# Altium schematic sheet USB-C_BREAKOUT_COMPONENTS.SchDoc(TOP)
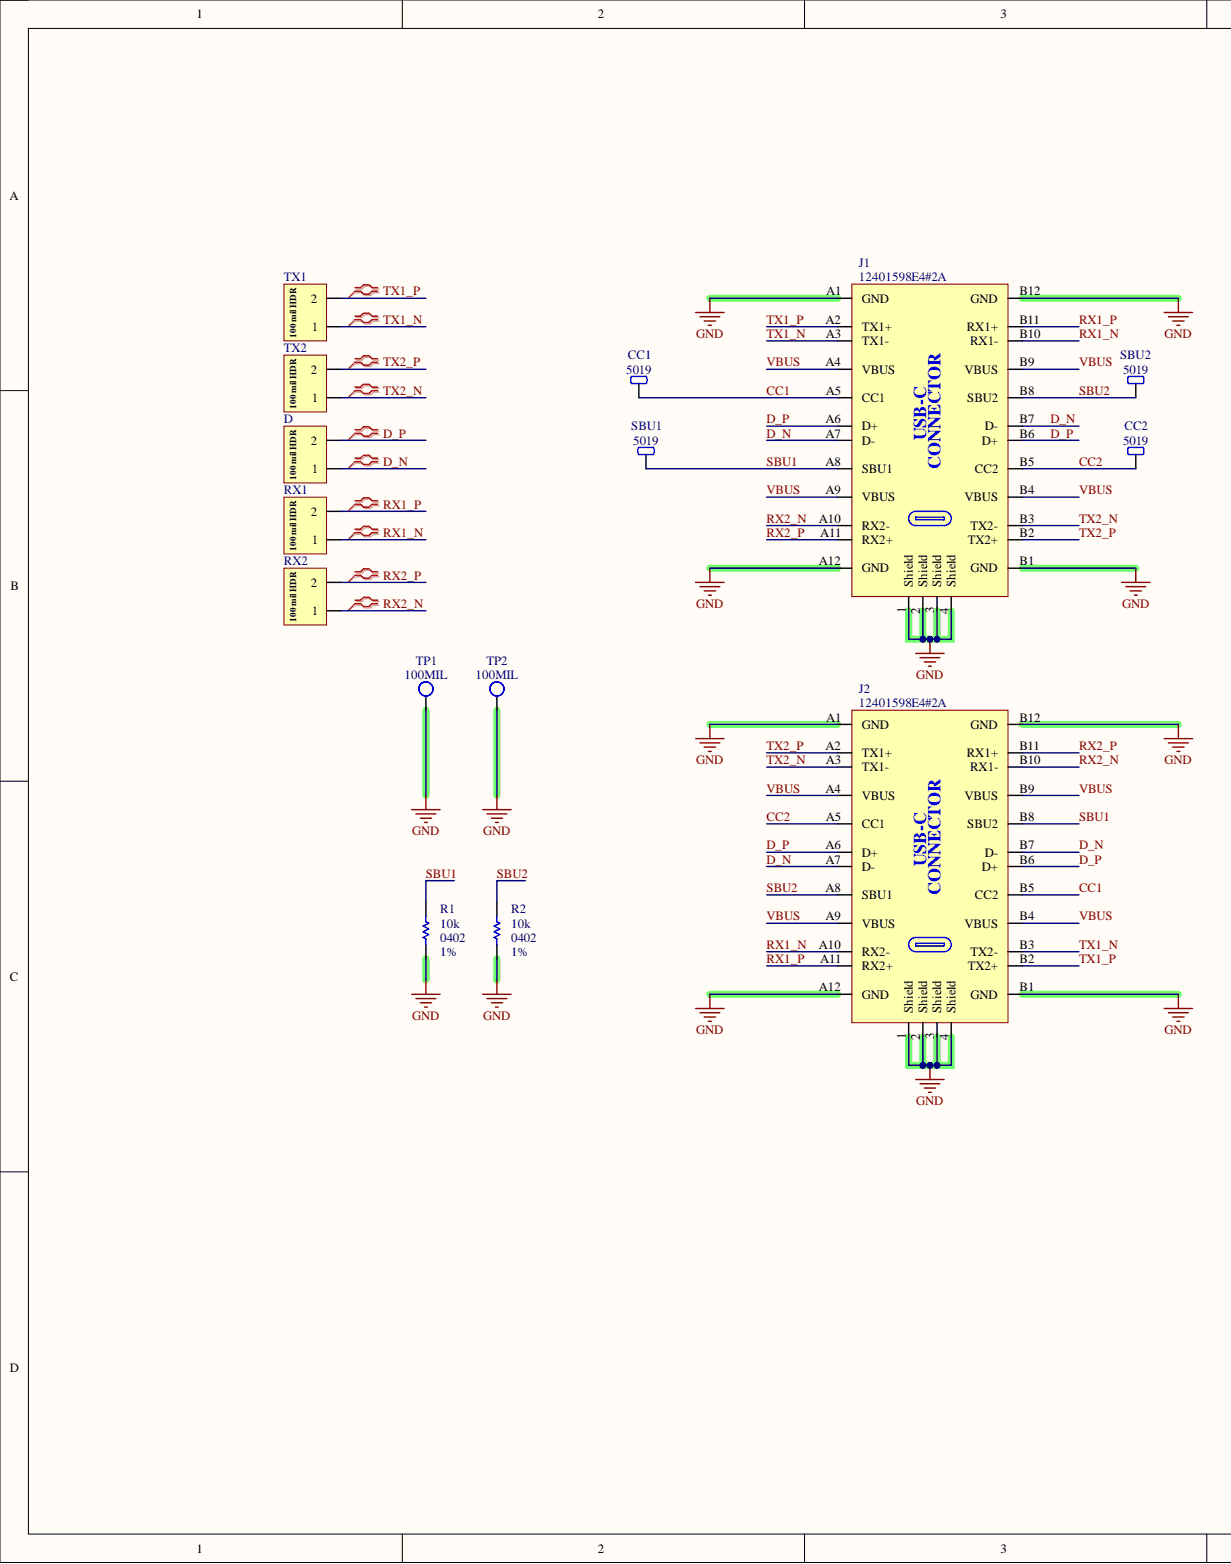
[diagram p0: USB-C_BREAKOUT_COMPONENTS.SchDoc, left]
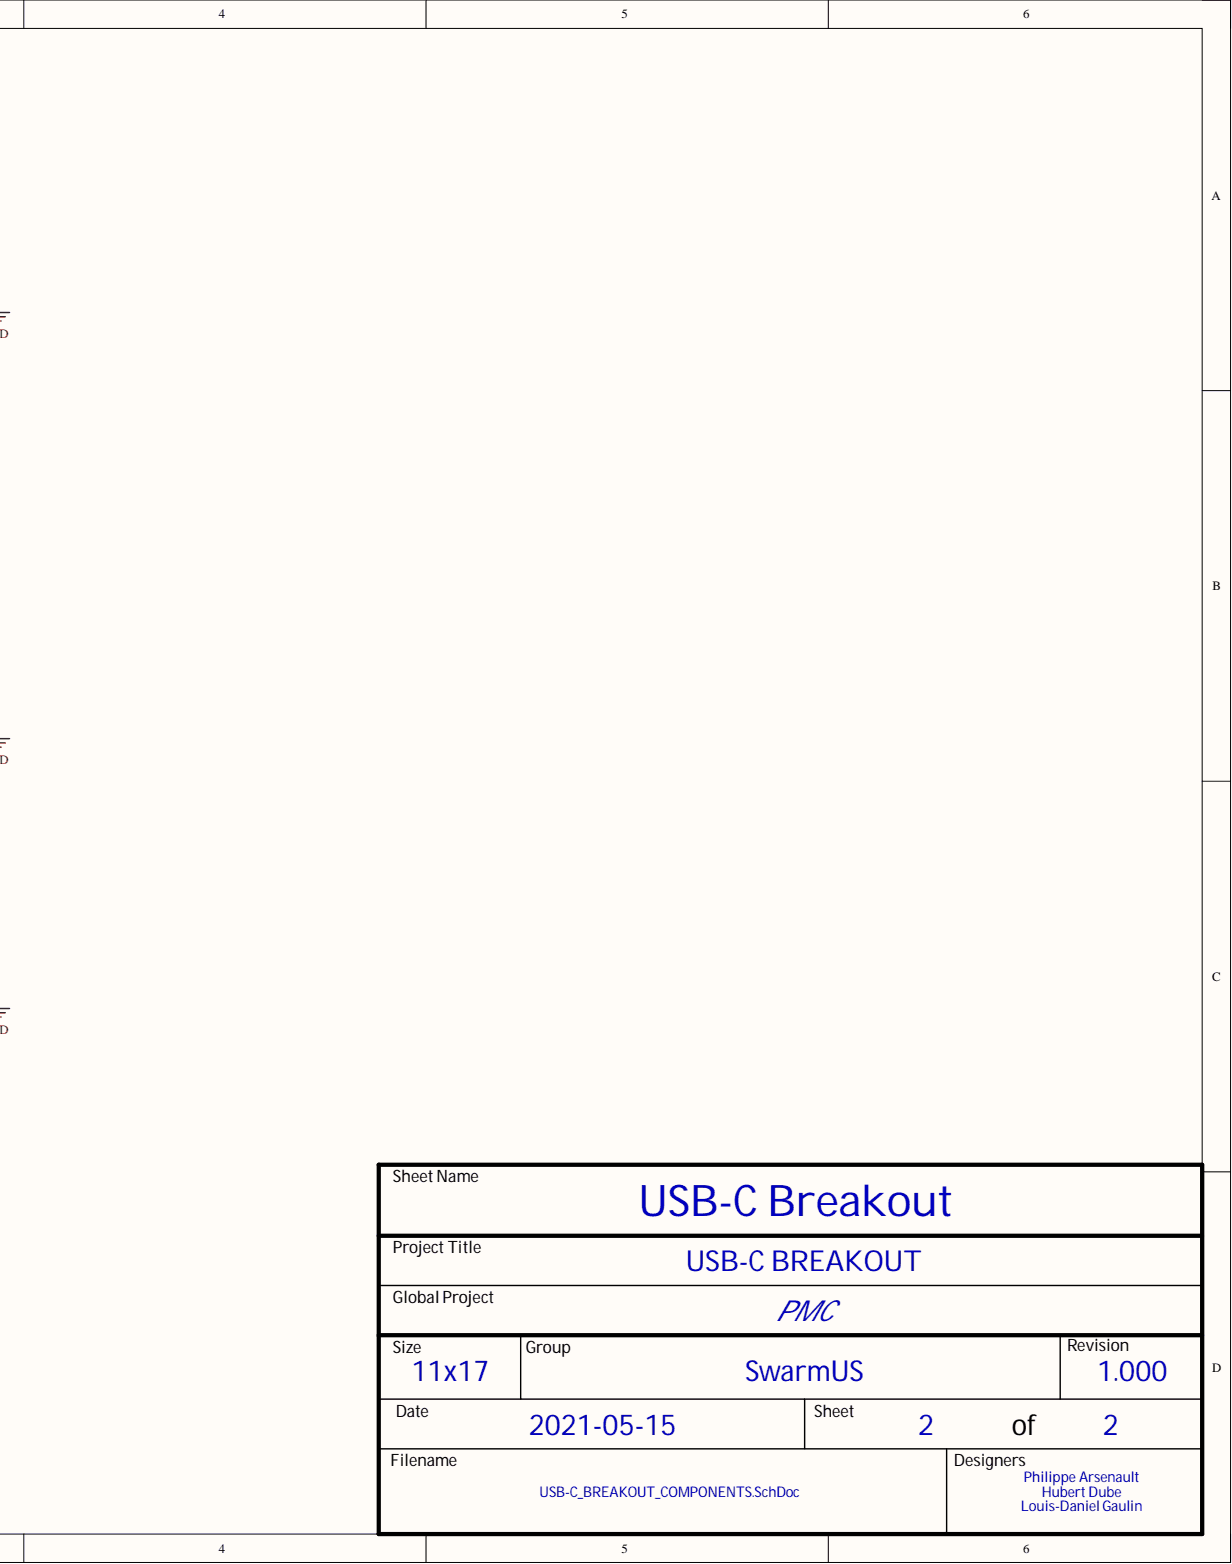
[diagram p1: USB-C_BREAKOUT_COMPONENTS.SchDoc, right]

## Parts
CC1  5019  [TPO_SMD_5019]
CC2  5019  [TPO_SMD_5019]
D  TS-102-G-A  [CON_HDR_TS-102-G-A]  (value not drawn)
J1  12401598E4#2A  [CON_USB_3.2_TypeC_12401598E4#2A]
J2  12401598E4#2A  [CON_USB_3.2_TypeC_12401598E4#2A]
R1  value 10k  Package: 0402  Tolerance: 1%  [RES_0402]  (hidden comment: RC0402FR-0710KL)
R2  value 10k  Package: 0402  Tolerance: 1%  [RES_0402]  (hidden comment: RC0402FR-0710KL)
RX1  TS-102-G-A  [CON_HDR_TS-102-G-A]  (value not drawn)
RX2  TS-102-G-A  [CON_HDR_TS-102-G-A]  (value not drawn)
SBU1  5019  [TPO_SMD_5019]
SBU2  5019  [TPO_SMD_5019]
TP1  100MIL  [TPO_TH_ROUND_100MIL]  (no BOM)
TP2  100MIL  [TPO_TH_ROUND_100MIL]  (no BOM)
TX1  TS-102-G-A  [CON_HDR_TS-102-G-A]  (value not drawn)
TX2  TS-102-G-A  [CON_HDR_TS-102-G-A]  (value not drawn)

## Nets
GND: J1.1(Shield) J1.2(Shield) J1.3(Shield) J1.4(Shield) J1.A1(GND) J1.A12(GND) J1.B1(GND) J1.B12(GND) J2.1(Shield) J2.2(Shield) J2.3(Shield) J2.4(Shield) J2.A1(GND) J2.A12(GND) J2.B1(GND) J2.B12(GND) R1.2 R2.2 TP1.1 TP2.1
CC1: CC1.1 J1.A5(CC1) J2.B5(CC2)
CC2: CC2.1 J1.B5(CC2) J2.A5(CC1)
D_N: D.1 J1.A7(D-) J1.B7(D-) J2.A7(D-) J2.B7(D-)
D_P: D.2 J1.A6(D+) J1.B6(D+) J2.A6(D+) J2.B6(D+)
RX1_N: J1.B10(RX1-) J2.A10(RX2-) RX1.1
RX1_P: J1.B11(RX1+) J2.A11(RX2+) RX1.2
RX2_N: J1.A10(RX2-) J2.B10(RX1-) RX2.1
RX2_P: J1.A11(RX2+) J2.B11(RX1+) RX2.2
SBU1: J1.A8(SBU1) J2.B8(SBU2) R1.1 SBU1.1
SBU2: J1.B8(SBU2) J2.A8(SBU1) R2.1 SBU2.1
TX1_N: J1.A3(TX1-) J2.B3(TX2-) TX1.1
TX1_P: J1.A2(TX1+) J2.B2(TX2+) TX1.2
TX2_N: J1.B3(TX2-) J2.A3(TX1-) TX2.1
TX2_P: J1.B2(TX2+) J2.A2(TX1+) TX2.2
VBUS: J1.A4(VBUS) J1.A9(VBUS) J1.B4(VBUS) J1.B9(VBUS) J2.A4(VBUS) J2.A9(VBUS) J2.B4(VBUS) J2.B9(VBUS)

## Library data of the parts
CC1 CC2 SBU1 SBU2 = TPO_SMD_5019: TPO - PC TEST POINT MINI - SMD; Manufacturer #1: Keystone Electronics; Cryo: UNKNOWN; GRAMS Lib Spec: 2.8; Polarized: No; Value: 3.81mm x 2.03mm; Assembly Type: SMD; Component Kind: Standard; HelpURL: https://www.digikey.ca/product-detail/en/keystone-electronics/5019/36-5019CT-ND/3907344; Library Name: GRAMS_MASTER_LIB_SCH.SchLib; Magnetic Material: UNKNOWN; Package: SMD; Part Number #1: 5019; Supplier 1: Digi-Key; Supplier Part Number 1: 36-5019CT-ND; CreatedBy/Date: Olivier Bernard / 5/12/2017; VerifiedBy: Caroline Paulin / 5 juillet 2018
D RX1 RX2 TX1 TX2 = CON_HDR_TS-102-G-A: CON - HDR 2 position 0.100" - TH; Manufacturer #1: Samtec Inc.; Cryo: UNKNOWN; GRAMS Lib Spec: 2.8; Polarized: No; Assembly Type: TH; Component Kind: Standard; HelpURL: http://suddendocs.samtec.com/prints/tx-1xx-x-x-mkt1.pdf; Library Name: GRAMS_MASTER_LIB_SCH.SchLib; Magnetic Material: Unknown; Package: TH; Part Number #1: TS-102-G-A; Supplier 1: Digi-Key; Supplier Part Number 1: SAM1111-02-ND; Temperature: -55°C ~ 125°C; CreatedBy/Date: Joel Lemay 2018-06-19; VerifiedBy: Caroline Paulin - 10 sept 2018
J1 J2 = CON_USB_3.2_TypeC_12401598E4#2A: CON_USB - USB 3.2 Gen 2 (USB 3.1 Gen 2, Superspeed + (USB 3.1)) 24 pos Receptacle - R/A; Manufacturer #1: Amphenol ICC (Commercial Products); Current Rating: 5A total; GRAMS Lib Spec: 2.8; Magnetic Material: Unknown; Polarized: Yes; Value: USB 3.2 Type C; Assembly Type: SMD + TH; Component Kind: Standard; HelpURL: https://www.amphenol-icc.com/media/wysiwyg/files/drawing/12401598xxx2a.pdf; Package: SMD + TH R/A; Part Number #1: 12401598E4#2A; Power Max: -; Supplier 1: Digi-Key; Supplier Part Number 1: 12401598E4#2ACT-ND; Temperature: max 105°C; Voltage Rating: -; CreatedBy/Date: Louis-Daniel Gaulin / 07-04-2021; Library Name: SWARMUS_LIB.SchLib; VerifiedBy: Philippe Arsenault/2021-04-08
R1 R2 = RES_RC0402FR-0710KL: RES - 10K OHMS 1% 1/16W - 0402; Manufacturer #1: Yageo; Cryo: UNKNOWN; GRAMS Lib Spec: 2.8; Polarized: NO; Voltage Rating: 50V; Assembly Type: SMD; Component Kind: Standard; HelpURL: http://www.yageo.com/documents/recent/PYu-RC_Group_51_RoHS_L_9.pdf; Library Name: GRAMS_MASTER_LIB_SCH.SchLib; Magnetic Material: UNKNOWN; Part Number #1: RC0402FR-0710KL; Power Max: 1/16W; Supplier 1: Digi-Key; Supplier Part Number 1: 311-10.0KLRCT-ND; Temperature: -55°C ~ 155°C; CreatedBy/Date: Nicolas V. (2018-11-20); VerifiedBy: Nicolas V. (2018-11-20)
TP1 TP2 = TPO_TH_ROUND_100MIL: TPO - TEST POINT ROUND TH  - 100MIL; Cryo: UNKNOWN; GRAMS Lib Spec: 2.8c; Magnetic Material: NO; Assembly Type: NONE; Library Name: GRAMS_MASTER_LIB_SCH.SchLib; Package: 100 MIL round; CreatedBy/Date: Louis-Daniel Gaulin/ 14/01/2020; VerifiedBy: C.Paulin - 21/01/2020
